# Altium schematic sheet POWER.SchDoc(POWER)
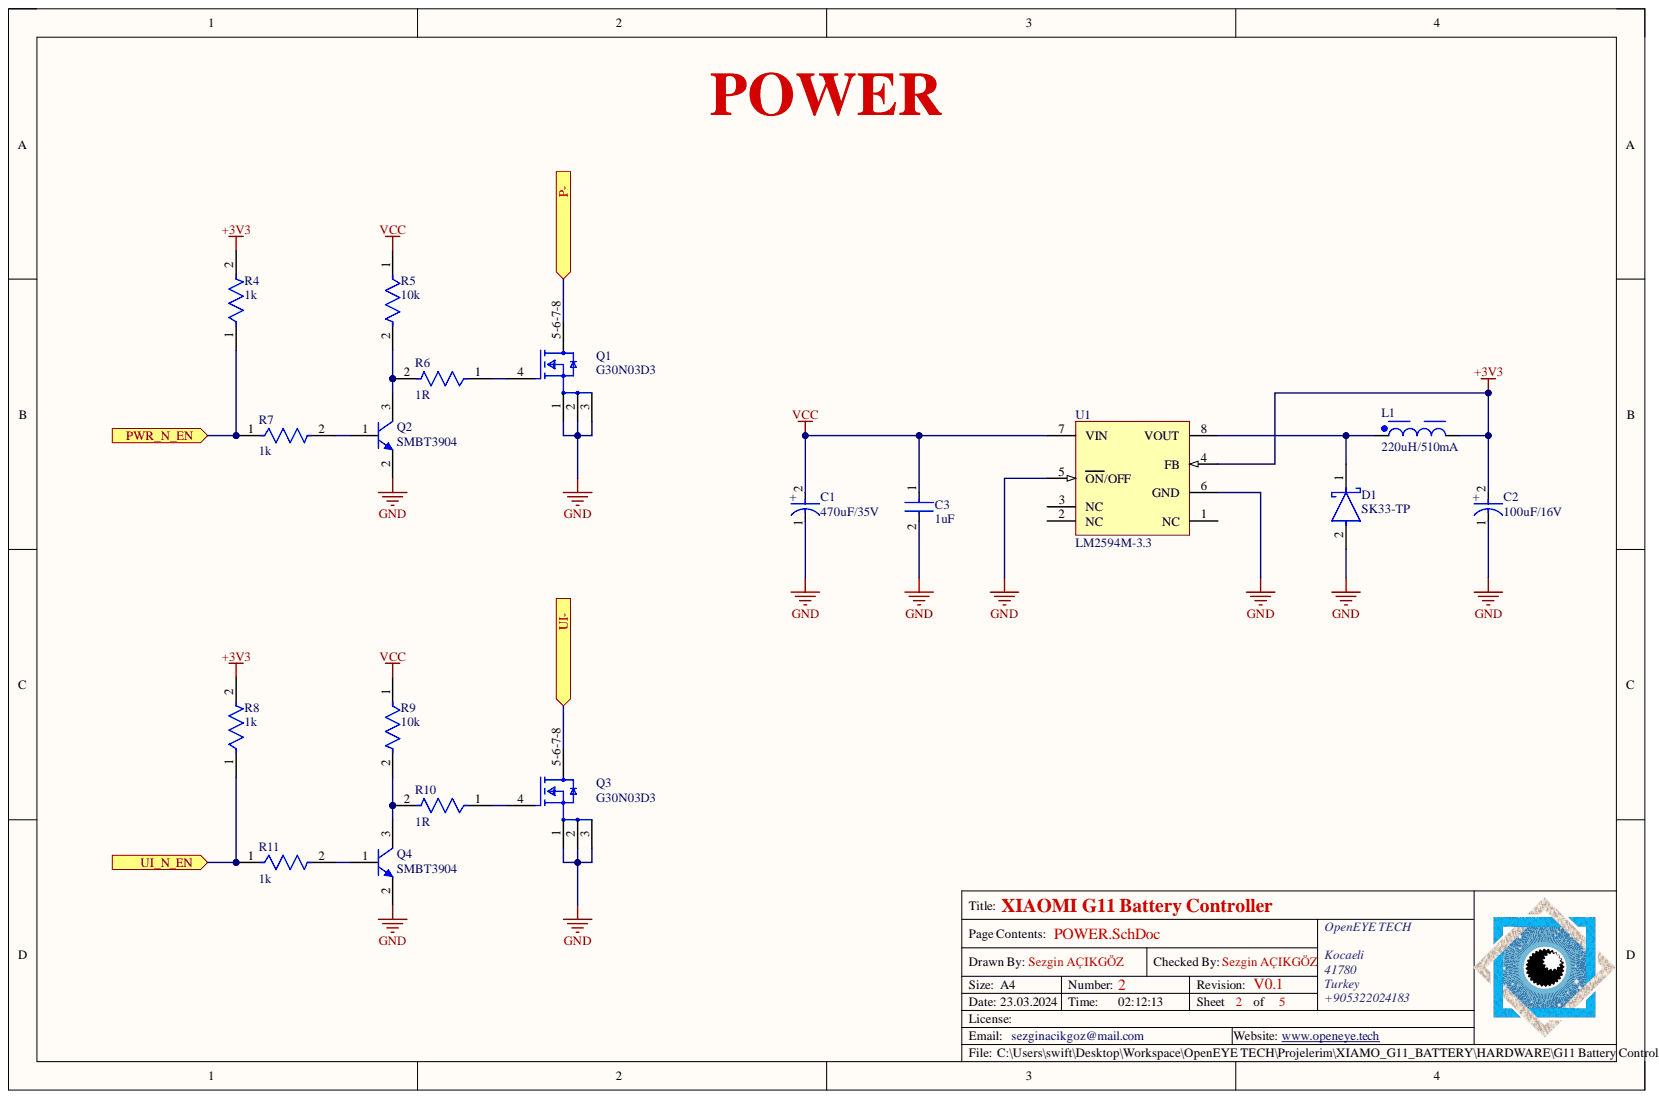
[diagram p0: POWER.SchDoc]

## Parts
C1  470uF/35V  [FP-FKSG-MFG]
C2  100uF/16V  [FP-TAJC-MFG]
C3  1uF  [FP-0603-L_1_6_0_15-W_0_8-IPC_C]
D1  SK33-TP  [FP-SMC-MFG]
L1  220uH/510mA  [WE-PD2_7850]
Q1  G30N03D3  [FP-511AB-MFG]
Q2  SMBT3904  [INF-SOT23_L]
Q3  G30N03D3  [FP-511AB-MFG]
Q4  SMBT3904  [INF-SOT23_L]
R4  1k  [FP-RP731J-MFG]
R5  10k  [FP-RP731J-MFG]
R6  1R  [FP-RP731J-MFG]
R7  1k  [FP-RP731J-MFG]
R8  1k  [FP-RP731J-MFG]
R9  10k  [FP-RP731J-MFG]
R10  1R  [FP-RP731J-MFG]
R11  1k  [FP-RP731J-MFG]
U1  LM2594M-3.3  [D0008A_M]

## Ports
P-, PWR_N_EN, UI-, UI_N_EN

## Nets
+3V3: C2.2 L1.2 R4.2 R8.2 U1.4(FB)
GND: C1.1 C2.1 C3.2 D1.2 Q1.1 Q1.2 Q1.3 Q2.2 Q3.1 Q3.2 Q3.3 Q4.2 U1.5(ON/OFF) U1.6(GND)
VCC: C1.2 C3.1 R5.1 R9.1 U1.7(VIN)
P-: Q1.5-6-7-8 ; port P-
PWR_N_EN: R4.1 R7.1 ; port PWR_N_EN
UI-: Q3.5-6-7-8 ; port UI-
UI_N_EN: R8.1 R11.1 ; port UI_N_EN
NetD1_1: D1.1 L1.1 U1.8(VOUT)
NetQ1_4: Q1.4 R6.1
NetQ2_1: Q2.1 R7.2
NetQ2_3: Q2.3 R5.2 R6.2
NetQ3_4: Q3.4 R10.1
NetQ4_1: Q4.1 R11.2
NetQ4_3: Q4.3 R9.2 R10.2

Not connected: U1 1(NC) 2(NC) 3(NC)

## Library data of the parts
C1 = CMP-05427-000037-1: CAP ALUM 470UF 20% 35V SMD; ESR (Equivalent Series Resistance): 80mR; Life (Hours): 2000Hour; Polarity: Polar; Ripple Current: 552.5mA; Ripple Current (AC): 850mA; Capacitance: 470uF; Case/Package: Radial; Component Kind: Standard; Diameter: 10mm; Height: 10.2mm; Height - Seated (Max): 0.413inch; LCSC Part #: C280413; Library Name: Altium Content Vault; Max Operating Temperature: 105°C; Min Operating Temperature: -55°C; Mount: Surface Mount; Package Quantity: 500; Packaging: Cut Tape; RoHS Compliant: Yes; Tolerance: 20%; Voltage Rating: 35V; Voltage Rating (DC): 35V
C2 = CMP-2100-03691-2: 100uF 16V  ±10% 1Ω 2312  SMD Tantalum Capacitor; Dissipation Factor: 8%; ESR (Equivalent Series Resistance): 1R; Leakage Current: 16uA; Polarity: Polar; Capacitance: 100uF; Case Code (Metric): 6032; Case Code (Imperial): 2412; Case/Package: Molded; Component Kind: Standard; Construction: Flat; Depth: 3.2mm; Features: General Purpose; Height: 2.8mm; Height - Seated (Max): 0.11inch; LCSC Part #: C122646; Lead Free: Lead Free; Length: 6mm; Library Name: Altium Content Vault; Max Operating Temperature: 125°C; Min Operating Temperature: -55°C; Mount: Surface Mount; Number of Pins: 2; Package Quantity: 1; Packaging: Tape and Reel; Radiation Hardening: No; REACH SVHC: No SVHC; RoHS Compliant: Yes; Series: TAJ; Termination: SMD/SMT; Tolerance: 10%; Voltage Rating: 16V; Voltage Rating (DC): 16V; Width: 3.2mm
C3 = CMP-2000-07531-4: Ceramic Capacitor General Use 1uF ±10% 50V X7R 0603; Capacitance: 1uF; Case Code (Metric): 1608; Case Code (Imperial): 0603; Case/Package: 0603; Component Kind: Standard; Construction: Flat; Depth: 0.8mm; Dielectric: X7R; Halogen Free: Halogen Free; Height: 0.8mm; LCSC Part #: C478859; Length: 1.6mm; Library Name: Altium Content Vault; Max Operating Temperature: 125°C; Min Operating Temperature: -55°C; Mount: Surface Mount; Package Quantity: 1; Packaging: Tape and Reel; Radiation Hardening: No; RoHS Compliant: Yes; Series: M; Termination: SMD/SMT; Thickness: 0.037inch; Tolerance: 10%; Voltage Rating: 50V; Voltage Rating (DC): 50V; Width: 0.031inch
D1 = CMP-56206-000007-1: DIODE SCHOTTKY 30V 3A DO214AB; Capacitance: 250pF; Element Configuration: Single; Forward Voltage: 500mV; Max Forward Surge Current (Ifsm): 100A; Max Reverse Leakage Current: 500uA; Polarity: Standard; Average Rectified Current: 3A; Case/Package: SMC; Component Kind: Standard; Current Rating: 3A; Forward Current: 3A; Height: 2.417mm; LCSC Part #: C151786; Lead Free: Lead Free; Length: 7.11mm; Library Name: Altium Content Vault; Max Operating Temperature: 150°C; Max Repetitive Reverse Voltage (Vrrm): 30V; Max Reverse Voltage (DC): 30V; Max Surge Current: 100A; Min Operating Temperature: -55°C; Mount: Surface Mount; Number of Pins: 2; Output Current: 3A; Package Quantity: 1; Packaging: Tape and Reel; Peak Non-Repetitive Surge Current: 100A; Peak Reverse Current: 500uA; Radiation Hardening: No; Voltage Rating (DC): 30V; Width: 6.22mm
L1 = CMP-0227-00452-2: NexarPartId: 22803417; Current Rating: 510mA; DC Resistance (DCR): 614mΩ; Self Resonant Frequency: 5MHz; Inductance: 220µH; Inductance Tolerance: 10%; Ambient Temperature Range High: 85°C; Ambient Temperature Range Low: -40°C; Component Kind: Standard; Height: 5mm; LCSC Part #: C2929444; Length: 7mm; Library Name: Altium Content Vault; Max Operating Temperature: 125°C; Min Operating Temperature: -40°C; Number of Pins: 2; Packaging: Bulk; Resistance: 614mΩ; RoHS: Compliant; Width: 7.8mm
Q1 Q3 = CMP-00278-71475164-1: C840054; Manufacturer Lifecycle Status: ACTIVE; Continuous Drain Current (ID): 20A; Drain to Source Breakdown Voltage: 40V; Drain to Source Resistance: 4.8mΩ; Gate to Source Voltage (Vgs): 20V; Max Junction Temperature (Tj): 175°C; Max Power Dissipation: 3.2W; Number of Channels: 1; Rds On Max: 3.8mΩ; Threshold Voltage: 2V; Turn-Off Delay Time: 17ns; Turn-On Delay Time: 9.3ns; Input Capacitance: 1.6nF; Component Kind: Standard; Drain to Source Voltage (Vdss): 40V; Height: 800µm; LCSC Part #: C840054; Lead Free: Lead Free; Library Name: Altium Content Vault; Lifecycle Status: Production; Max Operating Temperature: 175°C; Min Operating Temperature: -55°C; Mount: Surface Mount; NexarPartId: 71475164; Number of Pins: 8; Power Dissipation: 3.2W; REACH SVHC: No SVHC; RoHS: Compliant; Schedule B: 8541290080
Q2 = CMP-1178-00005-1: NPN Silicon Switching Transistor, 40 V VCEO, 200 mA IC, SOT23, Reel, Green; Manufacturer: Infineon; Green: TRUE; VCBO (max): 60 V; VCE: 1 V; VCEO (max): 40 V; VEBO (max): 6 V; IC: 10 mA; IC (max): 200 mA; ICBO (max): 50 nA; Component Kind: Standard; ComponentLink1Description: Manufacturer URL; ComponentLink1URL: http://www.infineon.com/; ComponentLink2Description: Datasheet; ComponentLink2URL: http://www.infineon.com/dgdl/smbt3904series_mmbt3904.pdf?folderId=db3a30431441fb5d011445fab851018e&fileId=db3a30431441fb5d0114460005c10191; ComponentLink3Description: Package Specification; ComponentLink3URL: http://www.infineon.com/dgdl/SOT23-Package_Overview.pdf?folderId=db3a30431936bc4b0119532290053c66&fileId=db3a30431936bc4b0119533468123c85; LCSC Part #: C896900; Library Name: Altium Content Vault; Mounting Technology: Surface Mount; PackageDescription: 3-Pin Small Outline Transistor Package, 1.3 x 2.9 mm Body, 0.95 mm Pitch; PackageReference: SOT23; PackageVersion: Apr-08; Packing: Reel; PartNumber: SMBT3904; Polarity: NPN; VCE (max): 1 V
Q4 = CMP-1178-00005-1: C896900; Manufacturer: Infineon; Green: TRUE; Packing: Reel; Polarity: NPN; VCBO (max): 60 V; VCE: 1 V; VCEO (max): 40 V; VEBO (max): 6 V; IC: 10 mA; IC (max): 200 mA; ICBO (max): 50 nA; Component Kind: Standard; ComponentLink1Description: Manufacturer URL; ComponentLink1URL: http://www.infineon.com/; ComponentLink2Description: Datasheet; ComponentLink2URL: http://www.infineon.com/dgdl/smbt3904series_mmbt3904.pdf?folderId=db3a30431441fb5d011445fab851018e&fileId=db3a30431441fb5d0114460005c10191; ComponentLink3Description: Package Specification; ComponentLink3URL: http://www.infineon.com/dgdl/SOT23-Package_Overview.pdf?folderId=db3a30431936bc4b0119532290053c66&fileId=db3a30431936bc4b0119533468123c85; LCSC Part #: C896900; Library Name: Altium Content Vault; Mounting Technology: Surface Mount; PackageDescription: 3-Pin Small Outline Transistor Package, 1.3 x 2.9 mm Body, 0.95 mm Pitch; PackageReference: SOT23; PackageVersion: Apr-08; PartNumber: SMBT3904; VCE (max): 1 V
R4 R7 R8 R11 = CMP-03211-008596-1: Manufacturer: TE Connectivity; Manufacturer Part Number: RP73D1J8R45BTDG; Power Rating: 0.1W; Resistance: 1kΩ; Temperature Coefficient: 15ppm/°C; Tolerance: 0.1%; Value: 1kΩ; Voltage Rating: 75V; Automotive: No; Case/Package: 603; Category: Res; Component Kind: Standard; ComponentLink2Description: Datasheet; ComponentLink2URL: https://www.te.com/commerce/DocumentDelivery/DDEController?Action=srchrtrv&DocNm=1773272&DocType=DS&DocLang=English; Composition: Thin Film; Device Class L1: Passive Components; Device Class L2: Resistors; Device Class L3: Chip SMD Resistors; Height: 0.55mm; Lead Free: Yes; Library Name: Altium Content Vault; Octopart: https://octopart.com/rp73d1j8r45btdg-te+connectivity-42630912; octopart_url: https://octopart.com/rp73d1j8r45btdg-te+connectivity-42630912; Package: 603; packaging: cut tape; RoHS: TRUE; Series: RP73; Temperature: -55°C to +105°C; Temperature Range High: +105°C; Temperature Range Low: -55°C; Ipc Land Pattern Name: RESC15580X45
R5 R9 = CMP-03211-008596-1: Manufacturer: TE Connectivity; Manufacturer Part Number: RP73D1J8R45BTDG; Power Rating: 0.1W; Resistance: 10kΩ; Temperature Coefficient: 15ppm/°C; Tolerance: 0.1%; Value: 10kΩ; Voltage Rating: 75V; Automotive: No; Case/Package: 603; Category: Res; Component Kind: Standard; ComponentLink2Description: Datasheet; ComponentLink2URL: https://www.te.com/commerce/DocumentDelivery/DDEController?Action=srchrtrv&DocNm=1773272&DocType=DS&DocLang=English; Composition: Thin Film; Device Class L1: Passive Components; Device Class L2: Resistors; Device Class L3: Chip SMD Resistors; Height: 0.55mm; Lead Free: Yes; Library Name: Altium Content Vault; Octopart: https://octopart.com/rp73d1j8r45btdg-te+connectivity-42630912; octopart_url: https://octopart.com/rp73d1j8r45btdg-te+connectivity-42630912; Package: 603; packaging: cut tape; RoHS: TRUE; Series: RP73; Temperature: -55°C to +105°C; Temperature Range High: +105°C; Temperature Range Low: -55°C; Ipc Land Pattern Name: RESC15580X45
R6 R10 = CMP-03211-008596-1: Manufacturer: TE Connectivity; Manufacturer Part Number: RP73D1J8R45BTDG; Power Rating: 0.1W; Resistance: 1Ω; Temperature Coefficient: 15ppm/°C; Tolerance: 0.1%; Value: 1Ω; Voltage Rating: 75V; Automotive: No; Case/Package: 603; Category: Res; Component Kind: Standard; ComponentLink2Description: Datasheet; ComponentLink2URL: https://www.te.com/commerce/DocumentDelivery/DDEController?Action=srchrtrv&DocNm=1773272&DocType=DS&DocLang=English; Composition: Thin Film; Device Class L1: Passive Components; Device Class L2: Resistors; Device Class L3: Chip SMD Resistors; Height: 0.55mm; Lead Free: Yes; Library Name: Altium Content Vault; Octopart: https://octopart.com/rp73d1j8r45btdg-te+connectivity-42630912; octopart_url: https://octopart.com/rp73d1j8r45btdg-te+connectivity-42630912; Package: 603; packaging: cut tape; RoHS: TRUE; Series: RP73; Temperature: -55°C to +105°C; Temperature Range High: +105°C; Temperature Range Low: -55°C; Ipc Land Pattern Name: RESC15580X45
U1 = CMP-0069-00878-3: SIMPLE SWITCHER® Power Converter 150 KHz 0.5A Step-Down Voltage Regulator, 8-pin Narrow SOIC; Manufacturer: Texas Instruments; PackageTopMarking: NSZXTT*2594*M-3.3; PowerWise: N; Code_JEDEC: MS-012-AA; Code_IPC: SOIC127P600-8; Component Kind: Standard; ComponentLink1Description: Datasheet URL; ComponentLink1URL: http://www.ti.com/general/docs/lit/getliterature.tsp?genericPartNumber=LM2594&fileType=pdf; CompType: Switcher; LCSC Part #: C3029048; Library Name: Altium Content Vault; PackageDescription: SOIC Narrow, 4.9 x 3.9 x 1.45mm, 8 Lead, 1.27mm Pitch; PackageReference: D0008A; PinCount: 8; RoHS: N
